# Altium schematic sheet 无人机无刷测速底板.SchDoc(无人机无刷测速底板)
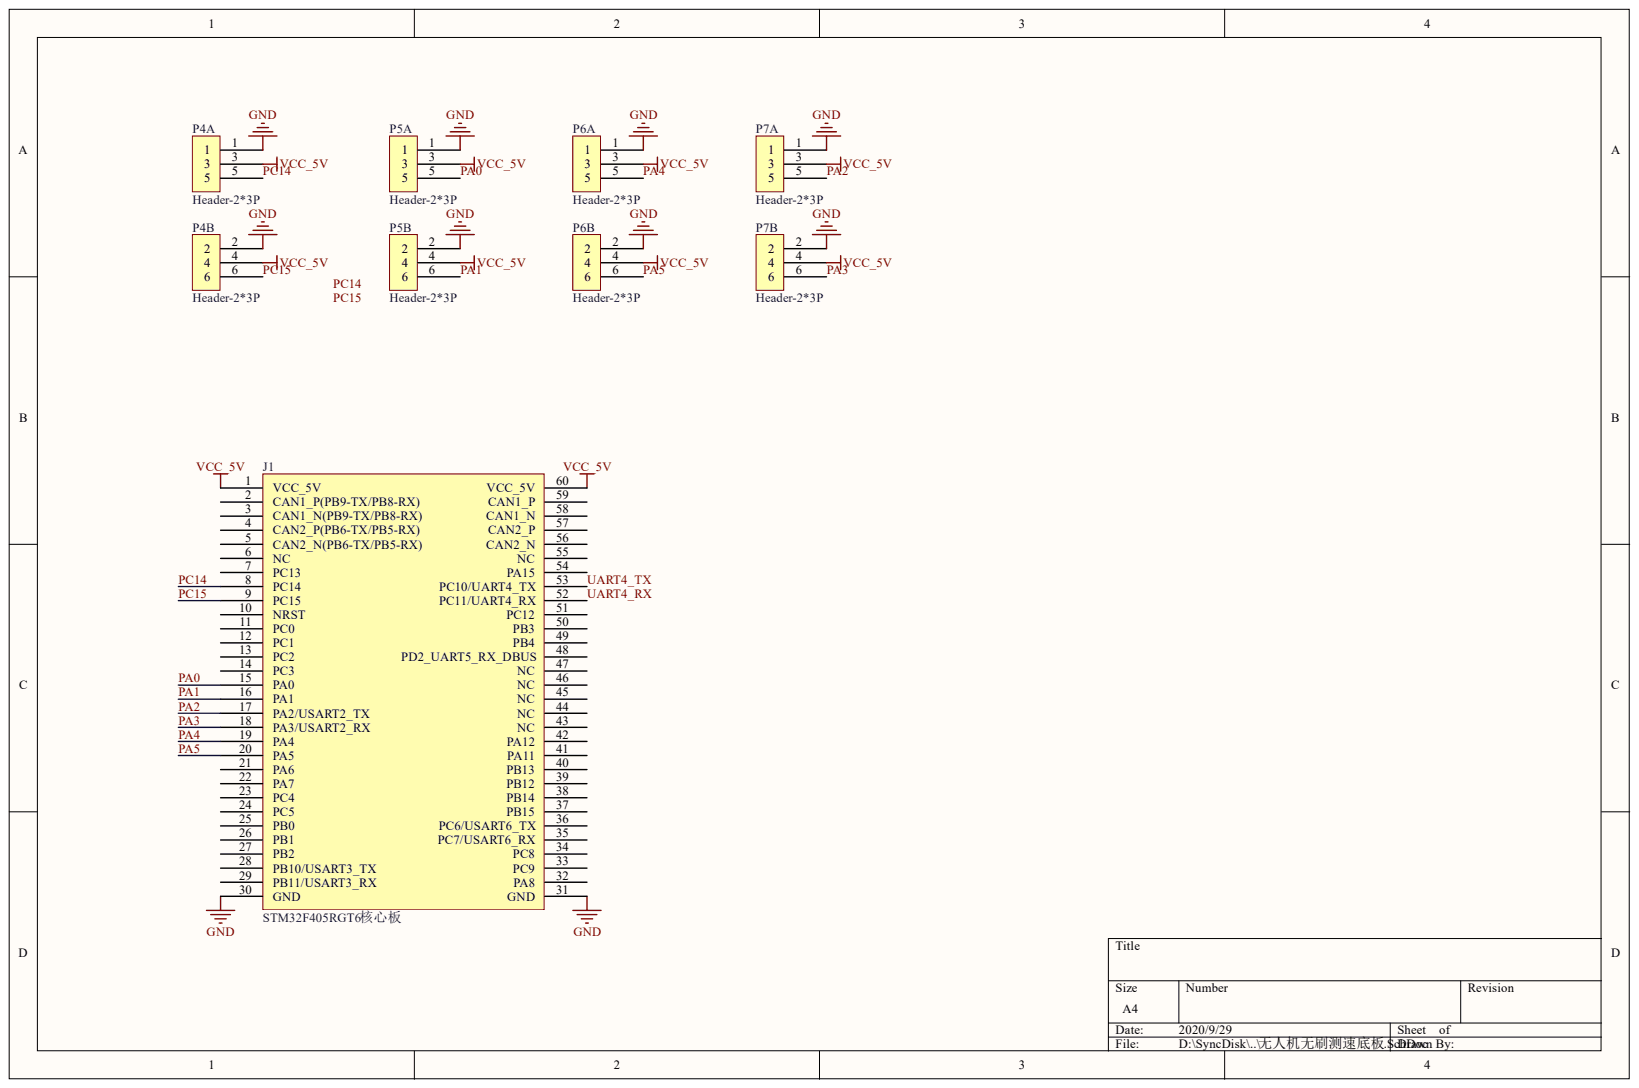
[diagram p0: 无人机无刷测速底板.SchDoc]

## Parts
J1  STM32F405RGT6核心板  [3710F060037G0FR01]
P4  Header-2*3P  [HDR2.54-WI-2x3P]  (parts A,B)
P5  Header-2*3P  [HDR2.54-WI-2x3P]  (parts A,B)
P6  Header-2*3P  [HDR2.54-WI-2x3P]  (parts A,B)
P7  Header-2*3P  [HDR2.54-WI-2x3P]  (parts A,B)

## Nets
GND: J1.30(GND) J1.31(GND) P4.1 P4.2 P5.1 P5.2 P6.1 P6.2 P7.1 P7.2
VCC_5V: J1.1(VCC_5V) J1.60(VCC_5V) P4.3 P4.4 P5.3 P5.4 P6.3 P6.4 P7.3 P7.4
PA0: J1.15(PA0) P5.5
PA1: J1.16(PA1) P5.6
PA2: J1.17(PA2/USART2_TX) P7.5
PA3: J1.18(PA3/USART2_RX) P7.6
PA4: J1.19(PA4) P6.5
PA5: J1.20(PA5) P6.6
PC14: J1.8(PC14) P4.5
PC15: J1.9(PC15) P4.6
UART4_RX: J1.52(PC11/UART4_RX)
UART4_TX: J1.53(PC10/UART4_TX)

Not connected: J1 2(CAN1_P(PB9-TX/PB8-RX)) 3(CAN1_N(PB9-TX/PB8-RX)) 4(CAN2_P(PB6-TX/PB5-RX)) 5(CAN2_N(PB6-TX/PB5-RX)) 6(NC) 7(PC13) 10(NRST) 11(PC0) 12(PC1) 13(PC2) 14(PC3) 21(PA6) 22(PA7) 23(PC4) 24(PC5) 25(PB0) 26(PB1) 27(PB2) 28(PB10/USART3_TX) 29(PB11/USART3_RX) 32(PA8) 33(PC9) 34(PC8) 35(PC7/USART6_RX) 36(PC6/USART6_TX) 37(PB15) 38(PB14) 39(PB12) 40(PB13) 41(PA11) 42(PA12) 43(NC) 44(NC) 45(NC) 46(NC) 47(NC) 48(PD2_UART5_RX_DBUS) 49(PB4) 50(PB3) 51(PC12) 54(PA15) 55(NC) 56(CAN2_N) 57(CAN2_P) 58(CAN1_N) 59(CAN1_P)

## Library data of the parts
J1 = STM32F405RGT6核心板: 3710(F/M)060037G0FR01; Component Kind: Standard; Library Name: Connectors.SchLib
P4-P7 = Header-2*3P: Component Kind: Standard; Description: Header-2*3P; Library Name: Connectors.SchLib
